# Altium schematic sheet 03-10715_Rev_0.1_Sheet_1.SchDoc
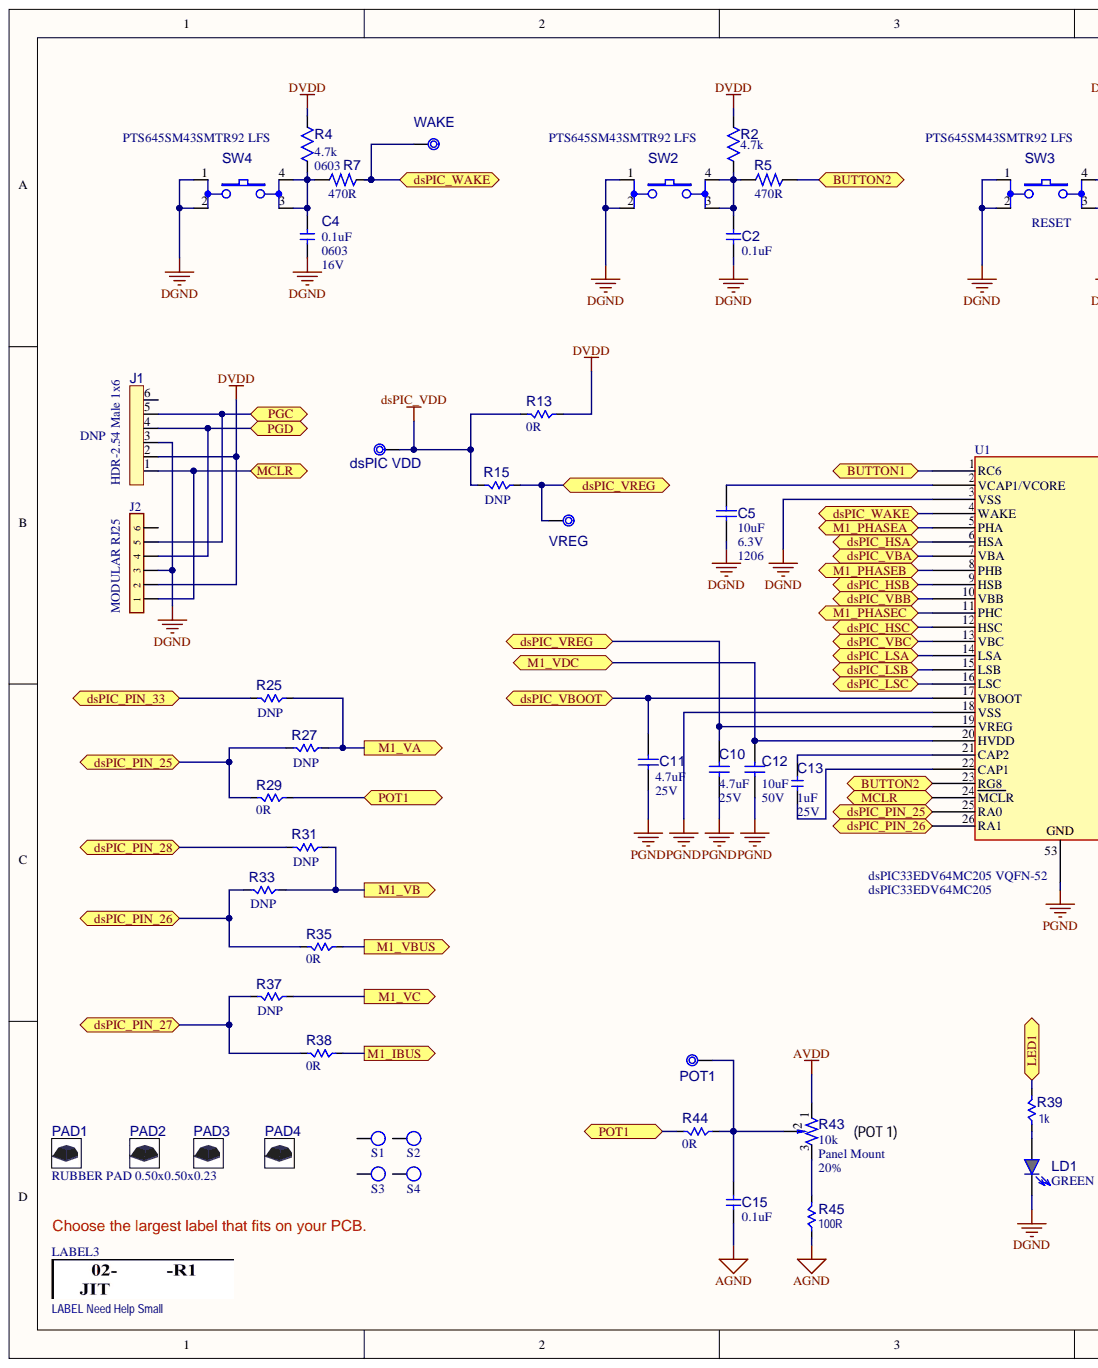
[diagram p0: 03-10715_Rev_0.1_Sheet_1.SchDoc, left]
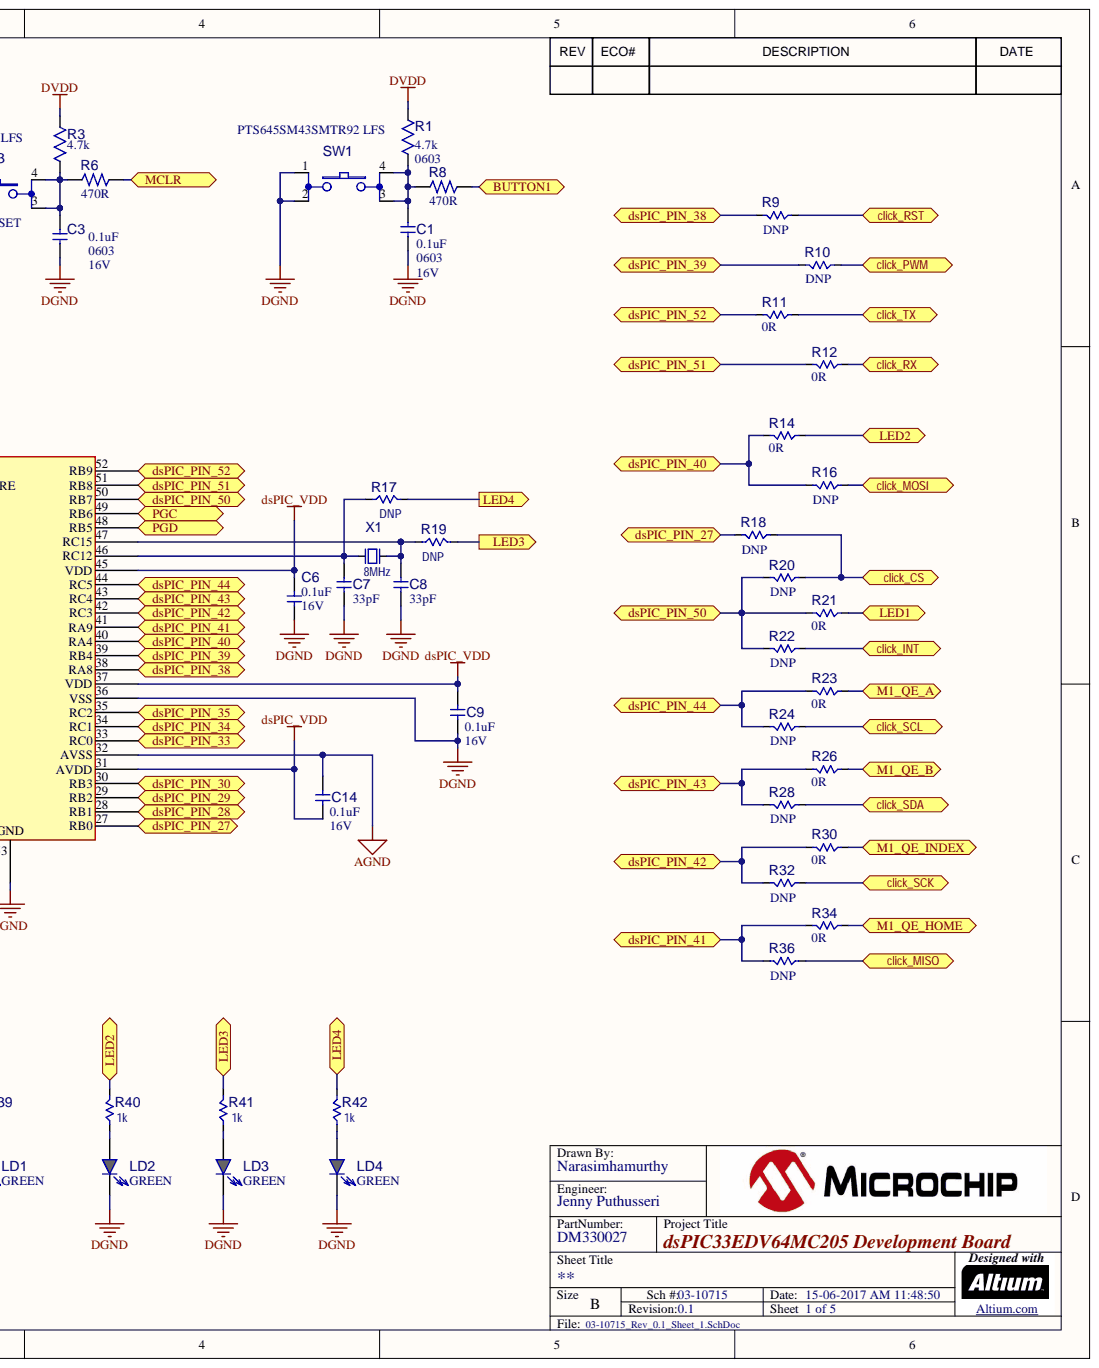
[diagram p1: 03-10715_Rev_0.1_Sheet_1.SchDoc, right]

## Parts
C1  value 0.1uF  Package: 0603  Voltage: 16V  [CAP_C_0603]  (hidden comment: Capacitor NP)
C2  value 0.1uF  [CAP_C_0603]  (hidden comment: Capacitor NP)
C3  value 0.1uF  Package: 0603  Voltage: 16V  [CAP_C_0603]  (hidden comment: Capacitor NP)
C4  value 0.1uF  Package: 0603  Voltage: 16V  [CAP_C_0603]  (hidden comment: Capacitor NP)
C5  value 10uF  Package: 1206  Voltage: 6.3V  [CAP_C_1206]  (hidden comment: Capacitor NP)
C6  value 0.1uF  Voltage: 16V  [CAP_C_0603]  (hidden comment: Capacitor NP)
C7  value 33pF  [CAP_C_0603]  (hidden comment: Capacitor NP)
C8  value 33pF  [CAP_C_0603]  (hidden comment: Capacitor NP)
C9  value 0.1uF  Voltage: 16V  [CAP_C_0603]  (hidden comment: Capacitor NP)
C10  value 4.7uF  Voltage: 25V  [CAP_C_0805]  (hidden comment: Capacitor NP)
C11  value 4.7uF  Voltage: 25V  [CAP_C_0805]  (hidden comment: Capacitor NP)
C12  value 10uF  Voltage: 50V  [CAP_C_2220]  (hidden comment: Capacitor NP)
C13  value 1uF  Voltage: 25V  [CAP_C_0805]  (hidden comment: Capacitor NP)
C14  value 0.1uF  Voltage: 16V  [CAP_C_0603]  (hidden comment: Capacitor NP)
C15  value 0.1uF  [CAP_C_0603]  (hidden comment: Capacitor NP)
dsPIC_VDD  dsPIC VDD  [TP_1.2x0.7]  (no BOM)
J1  value HDR-2.54 Male 1x6  Populated: DNP  [HDR_M_2.54_1x6_RA]  (hidden comment: Header 1x6)
J2  value MODULAR RJ25  [CON_JACK_RJ25_6P6C_TH_RA_TE_5555165-1]  (hidden comment: CON RJ-25)
LABEL3  value LABEL Need Help Small  [LABEL_08-00028]  (hidden comment: LABEL_08-00028)
LD1  value GREEN  [LED_0603_GREEN]  (hidden comment: LED_green)
LD2  value GREEN  [LED_0603_GREEN]  (hidden comment: LED_green)
LD3  value GREEN  [LED_0603_GREEN]  (hidden comment: LED_green)
LD4  value GREEN  [LED_0603_GREEN]  (hidden comment: LED_green)
PAD1  value RUBBER PAD 0.50x0.50x0.23  [BUMP_3M_SJ-5518_TAPERED_SQ_BLACK_L0.5W0.5H0.23]  (hidden comment: Rubber Pad SQ Taper)
PAD2  Rubber Pad SQ Taper  [BUMP_3M_SJ-5518_TAPERED_SQ_BLACK_L0.5W0.5H0.23]  (value not drawn)
PAD3  Rubber Pad SQ Taper  [BUMP_3M_SJ-5518_TAPERED_SQ_BLACK_L0.5W0.5H0.23]  (value not drawn)
PAD4  Rubber Pad SQ Taper  [BUMP_3M_SJ-5518_TAPERED_SQ_BLACK_L0.5W0.5H0.23]  (value not drawn)
POT1  POT1  [TP_1.2x0.7]  (no BOM)
R1  value 4.7k  Package: 0603  [RES_C_0603]  (hidden comment: Resistor)
R2  value 4.7k  [RES_C_0603]  (hidden comment: Resistor)
R3  value 4.7k  [RES_C_0603]  (hidden comment: Resistor)
R4  value 4.7k  Package: 0603  [RES_C_0603]  (hidden comment: Resistor)
R5  value 470R  [RES_C_0603]  (hidden comment: Resistor)
R6  value 470R  [RES_C_0603]  (hidden comment: Resistor)
R7  value 470R  Package: 0603  [RES_C_0603]  (hidden comment: Resistor)
R8  value 470R  Package: 0603  [RES_C_0603]  (hidden comment: Resistor)
R9  Populated: DNP  [RES_C_0603]  (hidden comment: Resistor)
R10  Populated: DNP  [RES_C_0603]  (hidden comment: Resistor)
R11  value 0R  [RES_C_0603]  (hidden comment: Resistor)
R12  value 0R  [RES_C_0603]  (hidden comment: Resistor)
R13  value 0R  [RES_C_0603]  (hidden comment: Resistor)
R14  value 0R  [RES_C_0603]  (hidden comment: Resistor)
R15  Populated: DNP  [RES_C_0603]  (hidden comment: Resistor)
R16  Populated: DNP  [RES_C_0603]  (hidden comment: Resistor)
R17  Populated: DNP  [RES_C_0603]  (hidden comment: RC_0805_No Load)
R18  Populated: DNP  [RES_C_0603]  (hidden comment: Resistor)
R19  Populated: DNP  [RES_C_0603]  (hidden comment: RC_0805_No Load)
R20  Populated: DNP  [RES_C_0603]  (hidden comment: Resistor)
R21  value 0R  [RES_C_0603]  (hidden comment: Resistor)
R22  Populated: DNP  [RES_C_0603]  (hidden comment: Resistor)
R23  value 0R  [RES_C_0603]  (hidden comment: Resistor)
R24  Populated: DNP  [RES_C_0603]  (hidden comment: Resistor)
R25  Populated: DNP  [RES_C_0603]  (hidden comment: Resistor)
R26  value 0R  [RES_C_0603]  (hidden comment: Resistor)
R27  Populated: DNP  [RES_C_0603]  (hidden comment: Resistor)
R28  Populated: DNP  [RES_C_0603]  (hidden comment: Resistor)
R29  value 0R  [RES_C_0603]  (hidden comment: Resistor)
R30  value 0R  [RES_C_0603]  (hidden comment: Resistor)
R31  Populated: DNP  [RES_C_0603]  (hidden comment: Resistor)
R32  Populated: DNP  [RES_C_0603]  (hidden comment: Resistor)
R33  Populated: DNP  [RES_C_0603]  (hidden comment: Resistor)
R34  value 0R  [RES_C_0603]  (hidden comment: Resistor)
R35  value 0R  [RES_C_0603]  (hidden comment: Resistor)
R36  Populated: DNP  [RES_C_0603]  (hidden comment: Resistor)
R37  Populated: DNP  [RES_C_0603]  (hidden comment: Resistor)
R38  value 0R  [RES_C_0603]  (hidden comment: Resistor)
R39  value 1k  [RES_C_0603]  (hidden comment: Resistor)
R40  value 1k  [RES_C_0603]  (hidden comment: Resistor)
R41  value 1k  [RES_C_0603]  (hidden comment: Resistor)
R42  value 1k  [RES_C_0603]  (hidden comment: Resistor)
R43  value 10k  Package: Panel Mount  Tolerance: 20%  [RES_VAR_TTE_RK09K1130A5R]  (hidden comment: Resistor Var)
R44  value 0R  [RES_C_0603]  (hidden comment: Resistor)
R45  value 100R  [RES_C_0603]  (hidden comment: Resistor)
S1  TP 66R42  [HW_4-40 screw]  (value not drawn)  (no BOM)
S2  TP 66R42  [HW_4-40 screw]  (value not drawn)  (no BOM)
S3  TP 66R42  [HW_4-40 screw]  (value not drawn)  (no BOM)
S4  TP 66R42  [HW_4-40 screw]  (value not drawn)  (no BOM)
SW1  value PTS645SM43SMTR92 LFS  Manufacturer Part Number 2: PTS645SM43SMTR92 LFS  [SW_TACT_SMD_B3S-1000]  (hidden comment: Switch 4 Pin)
SW2  value PTS645SM43SMTR92 LFS  Manufacturer Part Number 2: PTS645SM43SMTR92 LFS  [SW_TACT_SMD_B3S-1000]  (hidden comment: Switch 4 Pin)
SW3  value PTS645SM43SMTR92 LFS  Manufacturer Part Number 2: PTS645SM43SMTR92 LFS  [SW_TACT_SMD_B3S-1000]  (hidden comment: Switch 4 Pin)
SW4  value PTS645SM43SMTR92 LFS  Manufacturer Part Number 2: PTS645SM43SMTR92 LFS  [SW_TACT_SMD_B3S-1000]  (hidden comment: Switch 4 Pin)
U1  dsPIC33EDV64MC205 VQFN-52  value dsPIC33EDV64MC205  [VQFN-52_8x8]
VREG  VREG  [TP_1.2x0.7]  (no BOM)
WAKE  WAKE  [TP_1.2x0.7]  (no BOM)
X1  value 8MHz  [CRYSTAL_HC49-SMD-B]  (hidden comment: Crystal 2 Pins)

## Ports
BUTTON1, BUTTON2, click_CS, click_INT, click_MISO, click_MOSI, click_PWM, click_RST, click_RX, click_SCK, click_SCL, click_SDA, click_TX, dsPIC_HSA, dsPIC_HSB, dsPIC_HSC, dsPIC_LSA, dsPIC_LSB, dsPIC_LSC, dsPIC_PIN_25, dsPIC_PIN_26, dsPIC_PIN_27, dsPIC_PIN_28, dsPIC_PIN_29, dsPIC_PIN_30, dsPIC_PIN_33, dsPIC_PIN_34, dsPIC_PIN_35, dsPIC_PIN_38, dsPIC_PIN_39, dsPIC_PIN_40, dsPIC_PIN_41, dsPIC_PIN_42, dsPIC_PIN_43, dsPIC_PIN_44, dsPIC_PIN_50, dsPIC_PIN_51, dsPIC_PIN_52, dsPIC_VBA, dsPIC_VBB, dsPIC_VBC, dsPIC_VBOOT, dsPIC_VREG, dsPIC_WAKE, LED1, LED2, LED3, LED4, M1_IBUS, M1_PHASEA, M1_PHASEB, M1_PHASEC, M1_QE_A, M1_QE_B, M1_QE_HOME, M1_QE_INDEX, M1_VA, M1_VB, M1_VBUS, M1_VC, M1_VDC, MCLR, PGC, PGD, POT1

## Nets
AGND: C14.1 C15.2 R45.2 U1.32(AVSS)
AVDD: R43.1
DGND: C1.1 C2.2 C3.2 C4.1 C5.1 C6.2 C7.2 C8.2 C9.2 J1.3 J2.3 LD1.2 LD2.2 LD3.2 LD4.2 SW1.1 SW1.2 SW2.1 SW2.2 SW3.1 SW3.2 SW4.1 SW4.2 U1.3(VSS) U1.36(VSS)
dsPIC_VDD: C6.1 C9.1 C14.2 dsPIC_VDD.1 R13.1 R15.1 U1.31(AVDD) U1.37(VDD) U1.45(VDD)
DVDD: J1.2 J2.2 R1.1 R2.1 R3.1 R4.1 R13.2
PGND: C10.1 C11.1 C12.1 U1.18(VSS) U1.53
BUTTON1: R8.2 U1.1(RC6) ; port BUTTON1
BUTTON2: R5.2 U1.23(RG8) ; port BUTTON2
click_CS: R18.2 R20.2 ; port click_CS
click_INT: R22.2 ; port click_INT
click_MISO: R36.2 ; port click_MISO
click_MOSI: R16.2 ; port click_MOSI
click_PWM: R10.2 ; port click_PWM
click_RST: R9.2 ; port click_RST
click_RX: R12.2 ; port click_RX
click_SCK: R32.2 ; port click_SCK
click_SCL: R24.2 ; port click_SCL
click_SDA: R28.2 ; port click_SDA
click_TX: R11.2 ; port click_TX
dsPIC_HSA: U1.6(HSA) ; port dsPIC_HSA
dsPIC_HSB: U1.9(HSB) ; port dsPIC_HSB
dsPIC_HSC: U1.12(HSC) ; port dsPIC_HSC
dsPIC_LSA: U1.14(LSA) ; port dsPIC_LSA
dsPIC_LSB: U1.15(LSB) ; port dsPIC_LSB
dsPIC_LSC: U1.16(LSC) ; port dsPIC_LSC
dsPIC_PIN_25: R27.1 R29.1 U1.25(RA0) ; port dsPIC_PIN_25
dsPIC_PIN_26: R33.1 R35.1 U1.26(RA1) ; port dsPIC_PIN_26
dsPIC_PIN_27: R18.1 R37.1 R38.1 U1.27(RB0) ; port dsPIC_PIN_27
dsPIC_PIN_28: R31.1 U1.28(RB1) ; port dsPIC_PIN_28
dsPIC_PIN_29: U1.29(RB2) ; port dsPIC_PIN_29
dsPIC_PIN_30: U1.30(RB3) ; port dsPIC_PIN_30
dsPIC_PIN_33: R25.1 U1.33(RC0) ; port dsPIC_PIN_33
dsPIC_PIN_34: U1.34(RC1) ; port dsPIC_PIN_34
dsPIC_PIN_35: U1.35(RC2) ; port dsPIC_PIN_35
dsPIC_PIN_38: R9.1 U1.38(RA8) ; port dsPIC_PIN_38
dsPIC_PIN_39: R10.1 U1.39(RB4) ; port dsPIC_PIN_39
dsPIC_PIN_40: R14.1 R16.1 U1.40(RA4) ; port dsPIC_PIN_40
dsPIC_PIN_41: R34.1 R36.1 U1.41(RA9) ; port dsPIC_PIN_41
dsPIC_PIN_42: R30.1 R32.1 U1.42(RC3) ; port dsPIC_PIN_42
dsPIC_PIN_43: R26.1 R28.1 U1.43(RC4) ; port dsPIC_PIN_43
dsPIC_PIN_44: R23.1 R24.1 U1.44(RC5) ; port dsPIC_PIN_44
dsPIC_PIN_50: R20.1 R21.1 R22.1 U1.50(RB7) ; port dsPIC_PIN_50
dsPIC_PIN_51: R12.1 U1.51(RB8) ; port dsPIC_PIN_51
dsPIC_PIN_52: R11.1 U1.52(RB9) ; port dsPIC_PIN_52
dsPIC_VBA: U1.7(VBA) ; port dsPIC_VBA
dsPIC_VBB: U1.10(VBB) ; port dsPIC_VBB
dsPIC_VBC: U1.13(VBC) ; port dsPIC_VBC
dsPIC_VBOOT: C11.2 U1.17(VBOOT) ; port dsPIC_VBOOT
dsPIC_VREG: C10.2 R15.2 U1.19(VREG) VREG.1 ; port dsPIC_VREG
dsPIC_WAKE: R7.2 U1.4(WAKE) WAKE.1 ; port dsPIC_WAKE
LED1: R21.2 R39.1 ; port LED1
LED2: R14.2 R40.1 ; port LED2
LED3: R19.1 R41.1 ; port LED3
LED4: R17.1 R42.1 ; port LED4
M1_IBUS: R38.2 ; port M1_IBUS
M1_PHASEA: U1.5(PHA) ; port M1_PHASEA
M1_PHASEB: U1.8(PHB) ; port M1_PHASEB
M1_PHASEC: U1.11(PHC) ; port M1_PHASEC
M1_QE_A: R23.2 ; port M1_QE_A
M1_QE_B: R26.2 ; port M1_QE_B
M1_QE_HOME: R34.2 ; port M1_QE_HOME
M1_QE_INDEX: R30.2 ; port M1_QE_INDEX
M1_VA: R25.2 R27.2 ; port M1_VA
M1_VB: R31.2 R33.2 ; port M1_VB
M1_VBUS: R35.2 ; port M1_VBUS
M1_VC: R37.2 ; port M1_VC
M1_VDC: C12.2 U1.20(HVDD) ; port M1_VDC
MCLR: J1.1 J2.1 R6.2 U1.24(MCLR) ; port MCLR
PGC: J1.5 J2.5 U1.49(RB6) ; port PGC
PGD: J1.4 J2.4 U1.48(RB5) ; port PGD
POT1: R29.2 R44.1 ; port POT1
NetC1_2: C1.2 R1.2 R8.1 SW1.3 SW1.4
NetC2_1: C2.1 R2.2 R5.1 SW2.3 SW2.4
NetC3_1: C3.1 R3.2 R6.1 SW3.3 SW3.4
NetC4_2: C4.2 R4.2 R7.1 SW4.3 SW4.4
NetC5_2: C5.2 U1.2(VCAP1/VCORE)
NetC7_1: C7.1 R17.2 U1.46(RC12) X1.1
NetC8_1: C8.1 R19.2 U1.47(RC15) X1.2
NetC13_1: C13.1 U1.22(CAP1)
NetC13_2: C13.2 U1.21(CAP2)
NetC15_1: C15.1 POT1.1 R43.2 R44.2
NetLD1_1: LD1.1 R39.2
NetLD2_1: LD2.1 R40.2
NetLD3_1: LD3.1 R41.2
NetLD4_1: LD4.1 R42.2
NetR43_3: R43.3 R45.1

Not connected: J1 6; J2 6; S1 1; S2 1; S3 1; S4 1

## Library data of the parts
C1 C3 C4 C6 C9 C14 = CAP0011: Capacitor; Manufacturer 1: NIC Components; Manufacturer Part Number 1: NMC0603X7R104K16TRPF; Description_: CAP CER 0.1uF 16V 10% X7R SMD 0603; Populated: Yes; Temperature Coeffcient: X7R; Tolerance: 10%; Type: Ceramic; Category: Capacitor; Component Kind: Standard; ComponentLink1Description: Datasheet; ComponentLink1URL: https://chn-vm-apps.microchip.com/mchprepo/parts/Datasheets/nmc2.pdf; Database Table Name: Capacitor; Library Name: MCHP-CDB.DbLib; Manufacturer 2: Panasonic; Manufacturer 3: Taiyo Yuden; Manufacturer 4: Yageo; Manufacturer 5: Murata; Manufacturer 6: AVX; Manufacturer Part Number 2: ECJ-1VB1C104K; Manufacturer Part Number 3: EMK107B7104KA-T; Manufacturer Part Number 4: CC0603KRX7R7BB104; Manufacturer Part Number 5: GRM188R71C104KA01D; Manufacturer Part Number 6: 0603YC104KAT2A; MCHP-ID: CAP0011; Operating Temperature: -55C to +125C; Quantity Override: 1; Revision: 3; Status: Production; Supplier 1: NIC Components; Supplier 2: Digi-Key; Supplier 3: Digi-Key; Supplier 4: Newark; Supplier 5: Digi-Key; Supplier 6: Digi-Key; Supplier 7: Digi-Key; Supplier Part Number 1: NMC0603X7R104K16TRPF; Supplier Part Number 2: PCC1762CT-ND; Supplier Part Number 3: 587-1240-2-ND; Supplier Part Number 4: 86H5248; Supplier Part Number 5: 311-1088-1-ND; Supplier Part Number 6: 490-1532-1-ND; Supplier Part Number 7: 478-1239-1-ND
  C6 C9 C14: Package: 0603
C2 C15 = CAP0011: Capacitor; Manufacturer 1: NIC Components; Manufacturer Part Number 1: NMC0603X7R104K16TRPF; Description_: CAP CER 0.1uF 16V 10% X7R SMD 0603; Populated: Yes; Temperature Coeffcient: X7R; Tolerance: 10%; Type: Ceramic; Voltage: 16V; Category: Capacitor; Component Kind: Standard; ComponentLink1Description: Datasheet; ComponentLink1URL: https://chn-vm-apps.microchip.com/mchprepo/parts/Datasheets/nmc2.pdf; Database Table Name: Capacitor; Library Name: MCHP-CDB.DbLib; Manufacturer 2: Panasonic; Manufacturer 3: Taiyo Yuden; Manufacturer 4: Yageo; Manufacturer 5: Murata; Manufacturer 6: AVX; Manufacturer Part Number 2: ECJ-1VB1C104K; Manufacturer Part Number 3: EMK107B7104KA-T; Manufacturer Part Number 4: CC0603KRX7R7BB104; Manufacturer Part Number 5: GRM188R71C104KA01D; Manufacturer Part Number 6: 0603YC104KAT2A; MCHP-ID: CAP0011; Operating Temperature: -55C to +125C; Package: 0603; Quantity Override: 1; Revision: 3; Status: Production; Supplier 1: NIC Components; Supplier 2: Digi-Key; Supplier 3: Digi-Key; Supplier 4: Newark; Supplier 5: Digi-Key; Supplier 6: Digi-Key; Supplier 7: Digi-Key; Supplier Part Number 1: NMC0603X7R104K16TRPF; Supplier Part Number 2: PCC1762CT-ND; Supplier Part Number 3: 587-1240-2-ND; Supplier Part Number 4: 86H5248; Supplier Part Number 5: 311-1088-1-ND; Supplier Part Number 6: 490-1532-1-ND; Supplier Part Number 7: 478-1239-1-ND
C5 = CAP0233: Capacitor; Manufacturer 1: Panasonic; Manufacturer Part Number 1: ECJ-HVB0J106M; Description_: CAP CER 10uF 6.3V 20% X5R SMD 1206; Dev Tools Status: Production; Populated: Yes; Temperature Coeffcient: X5R; Tolerance: 20%; Type: Ceramic; Category: Capacitor; Component Kind: Standard; ComponentLink1Description: Datasheet; ComponentLink1URL: https://chn-vm-apps.microchip.com/mchprepo/parts/Datasheets/ECJ__General_.pdf; Database Table Name: Capacitor; Library Name: MCHP-CDB.DbLib; MCHP-ID: CAP0233; Operating Temperature: -55C to +85C; Quantity Override: 1; Revision: 1; Status: MCL Design; Supplier 1: Digi-Key; Supplier Part Number 1: PCC2317CT-ND; Symbol: Capacitor NP
C7 C8 = CAP0088: Capacitor; Manufacturer 1: Cal-Chip; Manufacturer Part Number 1: GMC10CG330J50NTLF; Description_: CAP CER 33pF 50V 5% NP0 SMD 0603; Populated: Yes; Temperature Coeffcient: NP0; Tolerance: 5%; Type: Ceramic; Voltage: 50V; Category: Capacitor; Component Kind: Standard; ComponentLink1Description: Datasheet; ComponentLink1URL: https://chn-vm-apps.microchip.com/mchprepo/parts/Datasheets/KEM_C1002_X7R_SMD.pdf; Database Table Name: Capacitor; Library Name: MCHP-CDB.DbLib; Manufacturer 2: KEMET; Manufacturer 3: Panasonic; Manufacturer 4: TDK; Manufacturer 5: Yageo; Manufacturer 6: NIC Components; Manufacturer 7: Panasonic; Manufacturer Part Number 2: C0603C330J5GACTU; Manufacturer Part Number 3: ECU-V1H330JCV; Manufacturer Part Number 4: C1608C0G1H330J; Manufacturer Part Number 5: CC0603JRNP09BN330; Manufacturer Part Number 6: NMC0603NPO330J50TRPF; Manufacturer Part Number 7: ECJ-1VC1H330J; MCHP-ID: CAP0088; Operating Temperature: -55C to +125C; Package: 0603; Quantity Override: 1; Revision: 1; Status: Production; Supplier 1: Cal-Chip; Supplier 2: Digi-Key; Supplier 3: Digi-Key; Supplier 4: Digi-Key; Supplier 5: Digi-Key; Supplier 6: NIC Components; Supplier 7: Panasonic; Supplier Part Number 1: GMC10CG330J50NTLF; Supplier Part Number 2: 399-1055-1-ND; Supplier Part Number 3: PCC330ACVTR-ND; Supplier Part Number 4: 445-1275-2-ND; Supplier Part Number 5: 311-1064-1-ND; Supplier Part Number 6: NMC0603NPO330J50TRPF; Supplier Part Number 7: ECJ-1VC1H330J
C10 C11 = CAP0549: Capacitor; Manufacturer 1: TDK; Manufacturer Part Number 1: C2012Y5V1E475Z; Description_: CAP CER 4.7uF 25V 20% Y5V SMD 0805; Dev Tools Status: Production; Populated: Yes; Temperature Coeffcient: Y5V; Tolerance: 20%; Type: Ceramic; Category: Capacitor; Component Kind: Standard; ComponentLink1Description: Datasheet; ComponentLink1URL: https://chn-vm-apps.microchip.com/mchprepo/parts/Datasheets/general_B11.pdf; Database Table Name: Capacitor; Library Name: MCHP-CDB-Offline.DbLib; MCHP-ID: CAP0549; Operating Temperature: -30C to +85C; Package: 0805; Quantity Override: 1; Revision: 1; Status: MCL Design; Supplier 1: Digi-Key; Supplier Part Number 1: 445-3461-2-ND; Symbol: Capacitor NP
C12 = CAP1253: Capacitor; Manufacturer 1: KEMET; Manufacturer Part Number 1: C2220X106K5RACTU; Description_: CAP CER 10uF 50V 10% X7R SMD 2220; Dev Tools Status: Production; Owner: Stephen Humberd; Populated: YES; Temperature Coeffcient: X7R; Tolerance: 10%; Type: Ceramic; Category: Capacitor; Component Kind: Standard; ComponentLink1Description: Datasheet; ComponentLink1URL: https://chn-vm-apps.microchip.com/mchprepo/parts/Datasheets/KEM_C1013_X7R_FT-CAP_SMD.pdf; Database Table Name: Capacitor; Library Name: MCHP-CDB-Offline.DbLib; MCHP-ID: CAP1253; Operating Temperature: -55°C ~ 125°C; Package: 2220; Quantity Override: 1; Revision: A; Status: MCL Design; Supplier 1: Digi-Key; Supplier Part Number 1: 399-5531-1-ND; Symbol: Capacitor NP
C13 = CAP0124: Capacitor; Manufacturer 1: KEMET; Manufacturer Part Number 1: C0805C105Z3VAC; Description_: CAP CER 1uF 25V 20% Y5V SMD 0805; Populated: Yes; Temperature Coeffcient: Y5V; Tolerance: 20%; Type: Ceramic; Category: Capacitor; Component Kind: Standard; ComponentLink1Description: Datasheet; ComponentLink1URL: https://chn-vm-apps.microchip.com/mchprepo/parts/Datasheets/Ceramic_Chip_Caps.pdf; Database Table Name: Capacitor; Library Name: MCHP-CDB.DbLib; Manufacturer 2: KEMET; Manufacturer 3: NIC Components; Manufacturer 4: Panasonic; Manufacturer Part Number 2: C0805C105Z3VACTU; Manufacturer Part Number 3: NMC0805Y5V105Z50TRFP; Manufacturer Part Number 4: ECJ-2FF1E105Z; MCHP-ID: CAP0124; Operating Temperature: -30C to + 85C; Package: 0805; Quantity Override: 1; Revision: 1; Status: Production; Supplier 1: KEMET; Supplier 2: Digi-Key; Supplier 3: NIC Components; Supplier 4: Digi-Key; Supplier Part Number 1: C0805C105Z3VAC; Supplier Part Number 2: 399-1293-1-ND; Supplier Part Number 3: NMC0805Y5V105Z50TRFP; Supplier Part Number 4: PCC2230TR-ND
dsPIC_VDD POT1 VREG WAKE = CON1128: Test Point; Database Table Name: Connector; Description_: CON TP Via_1.2x0.7; Owner: Bogdan P (M91398); Populated: Yes; Value: 1x1; Category: Connector; ComponentLink1Description: Datasheet; Library Name: MCHP-CDB.DbLib; MCHP-ID: CON1128; Quantity Override: 1; Revision: 0; Status: Production; Type: Test Point
J1 = CON1017: Manufacturer 1: FCI; Manufacturer Part Number 1: 68016-106HLF; Database Table Name: Connector; Description_: CON HDR-2.54 Male 1x6 Gold 5.84MH TH R/A; Dev Tools Status: Production; Owner: Leah Whitaker; Category: Connector; Component Kind: Standard; ComponentLink1Description: SVN Datasheet; ComponentLink1URL: https://chn-vm-apps.microchip.com/mchprepo/parts/Datasheets/68015.pdf; ComponentLink2Description: Local Datasheet; ComponentLink3Description: Open Symbol; ComponentLink4Description: Open Footprint; ComponentLink5Description: Open Footprint 2; ComponentLink6Description: Open Footprint 3; ComponentLink7Description: Open Footprint 4; Library Name: MCHP-CDB.DbLib; MCHP-ID: CON1017; Quantity Override: 1; Revision: 1; Status: MCL Design; Supplier 1: Digi-Key; Supplier Part Number 1: 609-3313-ND; Symbol: Header 1x6; Type: Header 2.54mm; ComponentLink2URL: C:\ALTIUM_WORK\00001_MCHP-CDB\Datasheets\68015.pdf; ComponentLink3URL: C:\ALTIUM_WORK\00001_MCHP-CDB\Library\LibraryRefs\Header 1x6.SchLib; ComponentLink4URL: C:\ALTIUM_WORK\00001_MCHP-CDB\Library\Footprints\HDR_M_2.54_1x6_RA.PcbLib
J2 = CON0052: Manufacturer 1: Tyco Electronics (TE Connectivity); Manufacturer Part Number 1: 5555165-1; Database Table Name: Connector; Description_: CON MODULAR RJ25 TH R/A; Dev Tools Status: Production; Populated: YES; Category: Connector; Component Kind: Standard; ComponentLink1Description: Datasheet; ComponentLink1URL: https://chn-vm-apps.microchip.com/mchprepo/parts/Datasheets/ENG_CD_5555165_E1.pdf; Library Name: MCHP-CDB.DbLib; MCHP-ID: CON0052; Quantity Override: 1; Revision: 1; Status: MCL Design; Supplier 1: Digi-Key; Supplier 2: Newark; Supplier Part Number 1: A31422-ND; Supplier Part Number 2: 42K8762; Symbol: CON RJ-25; Type: Modular
LABEL3 = LABEL_08-00028: Description_: LABEL, ASSY W/REV LEVEL (SMALL MODULES) PER MTS-0002; Dev Tools Status: Production; Populated: MECH; Category: Hardware; Component Kind: Standard; ComponentLink1Description: Datasheet; ComponentLink1URL: 08-00028R6.pdf; Library Name: LABEL_08-00028.SchLib; MCHP-ID: 08-00028; Supplier 1: Dev Tools; Type: Label
LD1-LD4 = DIODE1155: LED; Manufacturer 1: Lite-On Inc; Manufacturer Part Number 1: LTST-C191KGKT; Database Table Name: Diode; Description_: DIO LED GREEN 2V 30mA 35mcd Clear SMD 0603; Dev Tools Status: Production; Forward Current: 30mA; Owner: Tad Ahlvers; Populated: YES; Power: 75mW; Reverse Voltage: 5V; Type: LED Single; Category: Diode; Component Kind: Standard; ComponentLink1Description: Datasheet; ComponentLink1URL: https://chn-vm-apps.microchip.com/mchprepo/parts/Datasheets/S_110_LTST-C191KGKT.pdf; Library Name: MCHP-CDB.DbLib; MCHP-ID: DIODE1155; Operating Temperature: -55C to +85C; Quantity Override: 1; Revision: 1; Status: MCL Design; Supplier 1: Digi-Key; Supplier Part Number 1: 160-1446-1-ND; Symbol: LED_green
PAD1-PAD4 = MISC0022: Manufacturer 1: 3M; Manufacturer Part Number 1: SJ-5518; Database Table Name: Mechanical; Description_: MECH HW RUBBER PAD SQ Taper 0.50x0.50x0.23 Black; Dev Tools Status: Production; Populated: YES; Value: RUBBER PAD 0.50x0.50x0.23; Category: Hardware; Component Kind: Standard; ComponentLink1Description: SVN Datasheet; ComponentLink1URL: https://chn-vm-apps.microchip.com/mchprepo/parts/Datasheets/SJ-5518.pdf; ComponentLink2Description: Local Datasheet; ComponentLink3Description: Open Symbol; ComponentLink4Description: Open Footprint; ComponentLink5Description: Open Footprint 2; ComponentLink6Description: Open Footprint 3; ComponentLink7Description: Open Footprint 4; Library Name: MCHP-CDB.DbLib; MCHP-ID: MISC0022; Quantity Override: 1; Revision: 2; Status: MCL Design; Supplier 1: Digi-Key; Supplier Part Number 1: SJ5518-0-ND; Symbol: Rubber Pad SQ Taper; Type: Rubber Pad; ComponentLink2URL: C:\ALTIUM_WORK\00001_MCHP-CDB\Datasheets\SJ-5518.pdf; ComponentLink3URL: C:\ALTIUM_WORK\00001_MCHP-CDB\Library\LibraryRefs\Rubber Pad SQ Taper.SchLib; ComponentLink4URL: C:\ALTIUM_WORK\00001_MCHP-CDB\Library\Footprints\BUMP_3M_SJ-5518_TAPERED_SQ_BLACK_L0.5W0.5H0.23.PcbLib
R1-R4 = RSMT0628: Manufacturer 1: Panasonic; Manufacturer Part Number 1: ERJ-3EKF4701V; Description_: RES TKF 4.7k 1% 1/10W SMD 0603; Populated: Yes; Power: 1/10W; Temperature Coeffcient: 100ppm/C; Tolerance: 1%; Voltage: 75V; Category: Resistor; Component Kind: Standard; ComponentLink1Description: Datasheet; ComponentLink1URL: https://chn-vm-apps.microchip.com/mchprepo/parts/Datasheets/AOA0000CE2.pdf; Composition: TKF; Database Table Name: Resistor; Description: Resistor; Library Name: MCHP-CDB.DbLib; MCHP-ID: RSMT0628; Operating Temperature: -55C to +155C; Quantity Override: 1; Revision: 1; Status: Production; Supplier 1: Digi-Key; Supplier Part Number 1: P4.70KHCT-ND; Type: RES SMD
  R2 R3: Package: 0603
R5-R8 = RSMT0518: Manufacturer 1: Yageo; Manufacturer Part Number 1: RC0603FR-07470RL; Description_: RES TKF 470R 1% 1/10W SMD 0603; Populated: Yes; Power: 1/10W; Temperature Coeffcient: 100ppm/C; Tolerance: 1%; Voltage: 50V; Category: Resistor; Component Kind: Standard; ComponentLink1Description: Datasheet; ComponentLink1URL: https://chn-vm-apps.microchip.com/mchprepo/parts/Datasheets/PYu-RC0603_51_RoHS_L_4.pdf; Composition: TKF; Database Table Name: Resistor; Description: Resistor; Library Name: MCHP-CDB.DbLib; Manufacturer 2: Panasonic; Manufacturer 3: Stackpole Electronics Inc; Manufacturer Part Number 2: ERJ-3EKF4700V; Manufacturer Part Number 3: RMCF0603FT470R; MCHP-ID: RSMT0518; Operating Temperature: -55C to +155C; Quantity Override: 1; Revision: 1; Status: Production; Supplier 1: Digi-Key; Supplier 2: Digi-Key; Supplier 3: Digi-Key; Supplier Part Number 1: 311-470HRCT-ND; Supplier Part Number 2: P470HCT-ND; Supplier Part Number 3: RMCF1/16470FRTR-ND; Type: RES SMD
  R5 R6: Package: 0603
R9 R10 R15 R16 R18 R20 R22 R24 R25 R27 R28 R31-R33 R36 R37 = RSMT0001: Manufacturer 1: NIC Components; Manufacturer Part Number 1: NRC06Z0TRF; Description_: RES TKF 0R 1/10W SMD 0603; Power: 1/10W; Value: 0R; Category: Resistor; Component Kind: Standard; ComponentLink1Description: Datasheet; ComponentLink1URL: https://chn-vm-apps.microchip.com/mchprepo/parts/Datasheets/nrc.pdf; Composition: TKF; Database Table Name: Resistor; Description: Resistor; Library Name: MCHP-CDB.DbLib; Manufacturer 2: Panasonic; Manufacturer 3: Panasonic; Manufacturer 4: Stackpole Electronics Inc; Manufacturer 5: Vishay; Manufacturer 6: Stackpole Electronics Inc; Manufacturer 7: Yageo; Manufacturer 8: KOA Speer; Manufacturer 9: ROHM; Manufacturer Part Number 2: ERJ-3GSY0R00V; Manufacturer Part Number 3: ERJ-3GEY0R00V; Manufacturer Part Number 4: RMCF0603ZT0R00; Manufacturer Part Number 5: CRCW06030000Z0EA; Manufacturer Part Number 6: RMCF 1/16 0 R; Manufacturer Part Number 7: RC0603JR-070RL; Manufacturer Part Number 8: RK73Z1JTTP; Manufacturer Part Number 9: MCR03EZPJ000; MCHP-ID: RSMT0001; Operating Temperature: -55C to +155C; Package: 0603; Quantity Override: 1; Revision: 2; Status: Production; Supplier 1: NIC Components; Supplier 2: Digi-Key; Supplier 3: Digi-Key; Supplier 4: Digi-Key; Supplier 5: Digi-Key; Supplier 6: Digi-Key; Supplier 7: Digi-Key; Supplier 8: KOA Speer; Supplier 9: Digi-Key; Supplier Part Number 1: NRC06Z0TRF; Supplier Part Number 2: P0.0GTR-ND; Supplier Part Number 3: RMCF0603ZT0R00TR-ND; Supplier Part Number 4: RMCF0603ZT0R00CT-ND; Supplier Part Number 5: 541-0.0GCT-ND; Supplier Part Number 6: RMCF1/160RTR-ND; Supplier Part Number 7: 311-0.0GRCT-ND; Supplier Part Number 8: RK73Z1JTTP; Supplier Part Number 9: RHM0.0GCT-ND; Type: RES SMD
R11-R14 R21 R23 R26 R29 R30 R34 R35 R38 R44 = RSMT0001: Manufacturer 1: NIC Components; Manufacturer Part Number 1: NRC06Z0TRF; Description_: RES TKF 0R 1/10W SMD 0603; Populated: Yes; Power: 1/10W; Category: Resistor; Component Kind: Standard; ComponentLink1Description: Datasheet; ComponentLink1URL: https://chn-vm-apps.microchip.com/mchprepo/parts/Datasheets/nrc.pdf; Composition: TKF; Database Table Name: Resistor; Description: Resistor; Library Name: MCHP-CDB.DbLib; Manufacturer 2: Panasonic; Manufacturer 3: Panasonic; Manufacturer 4: Stackpole Electronics Inc; Manufacturer 5: Vishay; Manufacturer 6: Stackpole Electronics Inc; Manufacturer 7: Yageo; Manufacturer 8: KOA Speer; Manufacturer 9: ROHM; Manufacturer Part Number 2: ERJ-3GSY0R00V; Manufacturer Part Number 3: ERJ-3GEY0R00V; Manufacturer Part Number 4: RMCF0603ZT0R00; Manufacturer Part Number 5: CRCW06030000Z0EA; Manufacturer Part Number 6: RMCF 1/16 0 R; Manufacturer Part Number 7: RC0603JR-070RL; Manufacturer Part Number 8: RK73Z1JTTP; Manufacturer Part Number 9: MCR03EZPJ000; MCHP-ID: RSMT0001; Operating Temperature: -55C to +155C; Package: 0603; Quantity Override: 1; Revision: 2; Status: Production; Supplier 1: NIC Components; Supplier 2: Digi-Key; Supplier 3: Digi-Key; Supplier 4: Digi-Key; Supplier 5: Digi-Key; Supplier 6: Digi-Key; Supplier 7: Digi-Key; Supplier 8: KOA Speer; Supplier 9: Digi-Key; Supplier Part Number 1: NRC06Z0TRF; Supplier Part Number 2: P0.0GTR-ND; Supplier Part Number 3: RMCF0603ZT0R00TR-ND; Supplier Part Number 4: RMCF0603ZT0R00CT-ND; Supplier Part Number 5: 541-0.0GCT-ND; Supplier Part Number 6: RMCF1/160RTR-ND; Supplier Part Number 7: 311-0.0GRCT-ND; Supplier Part Number 8: RK73Z1JTTP; Supplier Part Number 9: RHM0.0GCT-ND; Type: RES SMD
R17 R19 = RSMT0001: Resistor; Manufacturer 1: NIC Components; Manufacturer Part Number 1: NRC06Z0TRF; Description_: RES TKF 0R 1/10W SMD 0603; Power: 1/10W; Value: 0R; Category: Resistor; Component Kind: Standard; ComponentLink1Description: Datasheet; ComponentLink1URL: https://chn-vm-apps.microchip.com/mchprepo/parts/Datasheets/nrc.pdf; Composition: TKF; Database Table Name: Resistor; Library Name: MCHP-CDB.DbLib; Manufacturer 2: Panasonic; Manufacturer 3: Panasonic; Manufacturer 4: Stackpole Electronics Inc; Manufacturer 5: Vishay; Manufacturer 6: Stackpole Electronics Inc; Manufacturer 7: Yageo; Manufacturer 8: KOA Speer; Manufacturer 9: ROHM; Manufacturer Part Number 2: ERJ-3GSY0R00V; Manufacturer Part Number 3: ERJ-3GEY0R00V; Manufacturer Part Number 4: RMCF0603ZT0R00; Manufacturer Part Number 5: CRCW06030000Z0EA; Manufacturer Part Number 6: RMCF 1/16 0 R; Manufacturer Part Number 7: RC0603JR-070RL; Manufacturer Part Number 8: RK73Z1JTTP; Manufacturer Part Number 9: MCR03EZPJ000; MCHP-ID: RSMT0001; Operating Temperature: -55C to +155C; Package: 0603; Quantity Override: 1; Revision: 2; Status: Production; Supplier 1: NIC Components; Supplier 2: Digi-Key; Supplier 3: Digi-Key; Supplier 4: Digi-Key; Supplier 5: Digi-Key; Supplier 6: Digi-Key; Supplier 7: Digi-Key; Supplier 8: KOA Speer; Supplier 9: Digi-Key; Supplier Part Number 1: NRC06Z0TRF; Supplier Part Number 2: P0.0GTR-ND; Supplier Part Number 3: RMCF0603ZT0R00TR-ND; Supplier Part Number 4: RMCF0603ZT0R00CT-ND; Supplier Part Number 5: 541-0.0GCT-ND; Supplier Part Number 6: RMCF1/160RTR-ND; Supplier Part Number 7: 311-0.0GRCT-ND; Supplier Part Number 8: RK73Z1JTTP; Supplier Part Number 9: RHM0.0GCT-ND; Type: RES SMD
R39-R42 = RSMT0138: Manufacturer 1: Panasonic; Manufacturer Part Number 1: ERJ-3EKF1001V; Description_: RES TKF 1k 1% 1/10W SMD 0603; Populated: Yes; Power: 1/10W; Temperature Coeffcient: 100ppm/C; Tolerance: 1%; Voltage: 75V; Category: Resistor; Component Kind: Standard; ComponentLink1Description: Datasheet; ComponentLink1URL: https://chn-vm-apps.microchip.com/mchprepo/parts/Datasheets/AOA0000CE2.pdf; Composition: TKF; Database Table Name: Resistor; Description: Resistor; Library Name: MCHP-CDB.DbLib; Manufacturer 2: ROHM; Manufacturer 3: Vishay; Manufacturer 4: Stackpole Electronics Inc; Manufacturer 5: Yageo; Manufacturer 6: Stackpole Electronics Inc; Manufacturer 7: Stackpole Electronics Inc; Manufacturer Part Number 2: MCR03EZPFX1001; Manufacturer Part Number 3: CRCW06031K00FKEA; Manufacturer Part Number 4: RMCF1/161.0K1%TR; Manufacturer Part Number 5: RC0603FR-071KL; Manufacturer Part Number 6: RMCF0603FT1K00; Manufacturer Part Number 7: RMCF 1/16 1K 1% R; MCHP-ID: RSMT0138; Operating Temperature: -55C to +155C; Package: 0603; Quantity Override: 1; Revision: 2; Status: Production; Supplier 1: Digi-Key; Supplier 2: Digi-Key; Supplier 3: Digi-Key; Supplier 4: Newark; Supplier 5: Stackpole Electronics Inc; Supplier 6: Digi-Key; Supplier 7: Digi-Key; Supplier 8: Digi-Key; Supplier Part Number 1: P1.00KHTR-ND; Supplier Part Number 2: P1.00KHCT-ND; Supplier Part Number 3: RHM1.00KHCT-ND; Supplier Part Number 4: 52K8015; Supplier Part Number 5: RMCF1/161.0K1%TR; Supplier Part Number 6: 311-1.00KHRCT-ND; Supplier Part Number 7: RMCF1/161KFRTR-ND; Supplier Part Number 8: RMCF0603FT1K00TR-ND; Type: RES SMD
R43 = RES1661: Manufacturer 1: ALPS; Manufacturer Part Number 1: RK09K1130A5R; Circuit Type: Single Turn; Description_: RES VARIABLE 10K 20% TH; Dev Tools Status: Production; Owner: Tim Moffat; Populated: YES; Power: 1/5W; Category: Resistor; Component Kind: Standard; ComponentLink1Description: SVN Datasheet; ComponentLink2Description: Local Datasheet; ComponentLink3Description: Open Symbol; ComponentLink4Description: Open Footprint; ComponentLink5Description: Open Footprint 2; ComponentLink6Description: Open Footprint 3; ComponentLink7Description: Open Footprint 4; Database Table Name: Resistor; Description: Resistor Var; Library Name: MCHP-CDB.DbLib; MCHP-ID: RES1661; Operating Temperature: -10C to +70C; Quantity Override: 1; Revision: 1; Status: MCL Design; Supplier 1: Mouser; Supplier Part Number 1: 688-RK09K1130A5R; Symbol: Resistor Var; Type: Variable; ComponentLink3URL: C:\ALTIUM_WORK\00001_MCHP-CDB\Library\LibraryRefs\Resistor Var.SchLib; ComponentLink4URL: C:\ALTIUM_WORK\00001_MCHP-CDB\Library\Footprints\RES_VAR_TTE_RK09K1130A5R.PcbLib
R45 = RSMT0324: Manufacturer 1: Panasonic; Manufacturer Part Number 1: ERJ-3EKF1000V; Description_: RES TKF 100R 1% 1/10W SMD 0603; Populated: Yes; Power: 1/10W; Temperature Coeffcient: 100ppm/C; Tolerance: 1%; Voltage: 75V; Category: Resistor; Component Kind: Standard; ComponentLink1Description: Datasheet; ComponentLink1URL: https://chn-vm-apps.microchip.com/mchprepo/parts/Datasheets/AOA0000CE2.pdf; Composition: TKF; Database Table Name: Resistor; Description: Resistor; Library Name: MCHP-CDB.DbLib; Manufacturer 2: Stackpole Electronics Inc; Manufacturer 3: Yageo; Manufacturer Part Number 2: RMCF 1/16 100 1% R; Manufacturer Part Number 3: RC0603FR-07100RL; MCHP-ID: RSMT0324; Operating Temperature: -55C to +155C; Package: 0603; Quantity Override: 1; Revision: 2; Status: Production; Supplier 1: Digi-Key; Supplier 2: Digi-Key; Supplier 3: Digi-Key; Supplier Part Number 1: P100HCT-ND; Supplier Part Number 2: RMCF1/16100FRTR-ND; Supplier Part Number 3: 311-100HRCT-ND; Type: RES SMD
S1-S4 = Test Point 66R42 No Pin: Description: Test Point 66R42 No Pin; Library Name: 750W PFC Board C.SCHLIB
SW1-SW4 = SWITCH1046: Switch; Manufacturer 1: Wurth Electronics Inc; Manufacturer Part Number 1: 430182043816; Description_: SWITCH TACT SPST 12V 50mA PTS645SM43SMTR92 LFS SMD; Dev Tools Status: Production; Owner: Jamus Griego; Populated: YES; Category: Switch; Component Kind: Standard; ComponentLink1Description: SVN Datasheet; ComponentLink1URL: https://chn-vm-apps.microchip.com/mchprepo/parts/Datasheets/PTS645_17dec14.pdf; ComponentLink2Description: Local Datasheet; ComponentLink3Description: Open Symbol; ComponentLink4Description: Open Footprint; ComponentLink5Description: Open Footprint 2; ComponentLink6Description: Open Footprint 3; ComponentLink7Description: Open Footprint 4; Database Table Name: Switch; Library Name: MCHP-CDB.DbLib; Manufacturer 2: C&K Components; Manufacturer 3: SWITECH; Manufacturer Part Number 3: TS2A-043C-G9; MCHP-ID: SWITCH1046; Quantity Override: 1; Revision: 1; Status: MCL Design; Supplier 1: Digi-Key; Supplier 2: Digi-Key; Supplier 3: Raynen; Supplier Part Number 1: 732-7004-1-ND; Supplier Part Number 2: CKN9112CT-ND; Supplier Part Number 3: SWITECH TS2A-043C-G9; Symbol: Switch 4 Pin; Type: Tactile; ComponentLink2URL: C:\ALTIUM_WORK\00001_MCHP-CDB\Datasheets\PTS645_17dec14.pdf; ComponentLink3URL: C:\ALTIUM_WORK\00001_MCHP-CDB\Library\LibraryRefs\Switch 4 Pin.SchLib; ComponentLink4URL: C:\ALTIUM_WORK\00001_MCHP-CDB\Library\Footprints\SW_TACT_SMD_B3S-1000.PcbLib
U1 = MIC7086: Manufacturer 1: Microchip Technology; Manufacturer Part Number 1: dsPIC33EDV64MC205-I/M7; Database Table Name: MCHP-Microcontroller; Description_: MCHP MCU 16-BIT 70MHz 64kB 8kB DSPIC33EDV64MC205-I/M7 VQFN-52; Dev Tools Status: Design; Owner: Narasimha Murthy; Populated: YES; Category: MCHP-Microcontroller; Component Kind: Standard; ComponentLink1Description: SVN Datasheet; ComponentLink2Description: Local Datasheet; ComponentLink3Description: Open Symbol; ComponentLink4Description: Open Footprint; ComponentLink5Description: Open Footprint 2; ComponentLink6Description: Open Footprint 3; ComponentLink7Description: Open Footprint 4; Library Name: MCHP-CDB.DbLib; MCHP-ID: MIC7086; Quantity Override: 1; Revision: A; Status: MCL Design; Supplier 1: Microchip; Supplier Part Number 1: dsPIC33EDV64MC205-I/M7; Symbol: dsPIC33EDV64MC205 VQFN-52; Type: 16-Bit; ComponentLink3URL: C:\ALTIUM_WORK\00001_MCHP-CDB\Library\LibraryRefs\dsPIC33EDV64MC205 VQFN-52.SchLib; ComponentLink4URL: C:\ALTIUM_WORK\00001_MCHP-CDB\Library\Footprints\VQFN-52_8x8.PcbLib
X1 = OSC0075: Crystal; Manufacturer 1: ECS Inc; Manufacturer Part Number 1: ECS-80-20-5PXDU-TR; Database Table Name: Crystal-Oscillator; Description_: CRYSTAL 8MHz 20pF SMD HC49/US; Load Capacitance: 20pF; Populated: Yes; Tolerance: 30ppm; Category: Crystal/Oscillator; Component Kind: Standard; ComponentLink1Description: Datasheet; ComponentLink1URL: https://chn-vm-apps.microchip.com/mchprepo/parts/Datasheets/CSM_7X_DU.pdf; Library Name: MCHP-CDB.DbLib; MCHP-ID: OSC0075; Operating Temperature: -55C to +125C; Package: SMD_HC49/US; Quantity Override: 1; Revision: 1; Status: Production; Supplier 1: Digi-Key; Supplier Part Number 1: XC1526TR-ND; Type: Crystal
